# Altium schematic sheet 2225B_VumetreFrequenciel.SchDoc
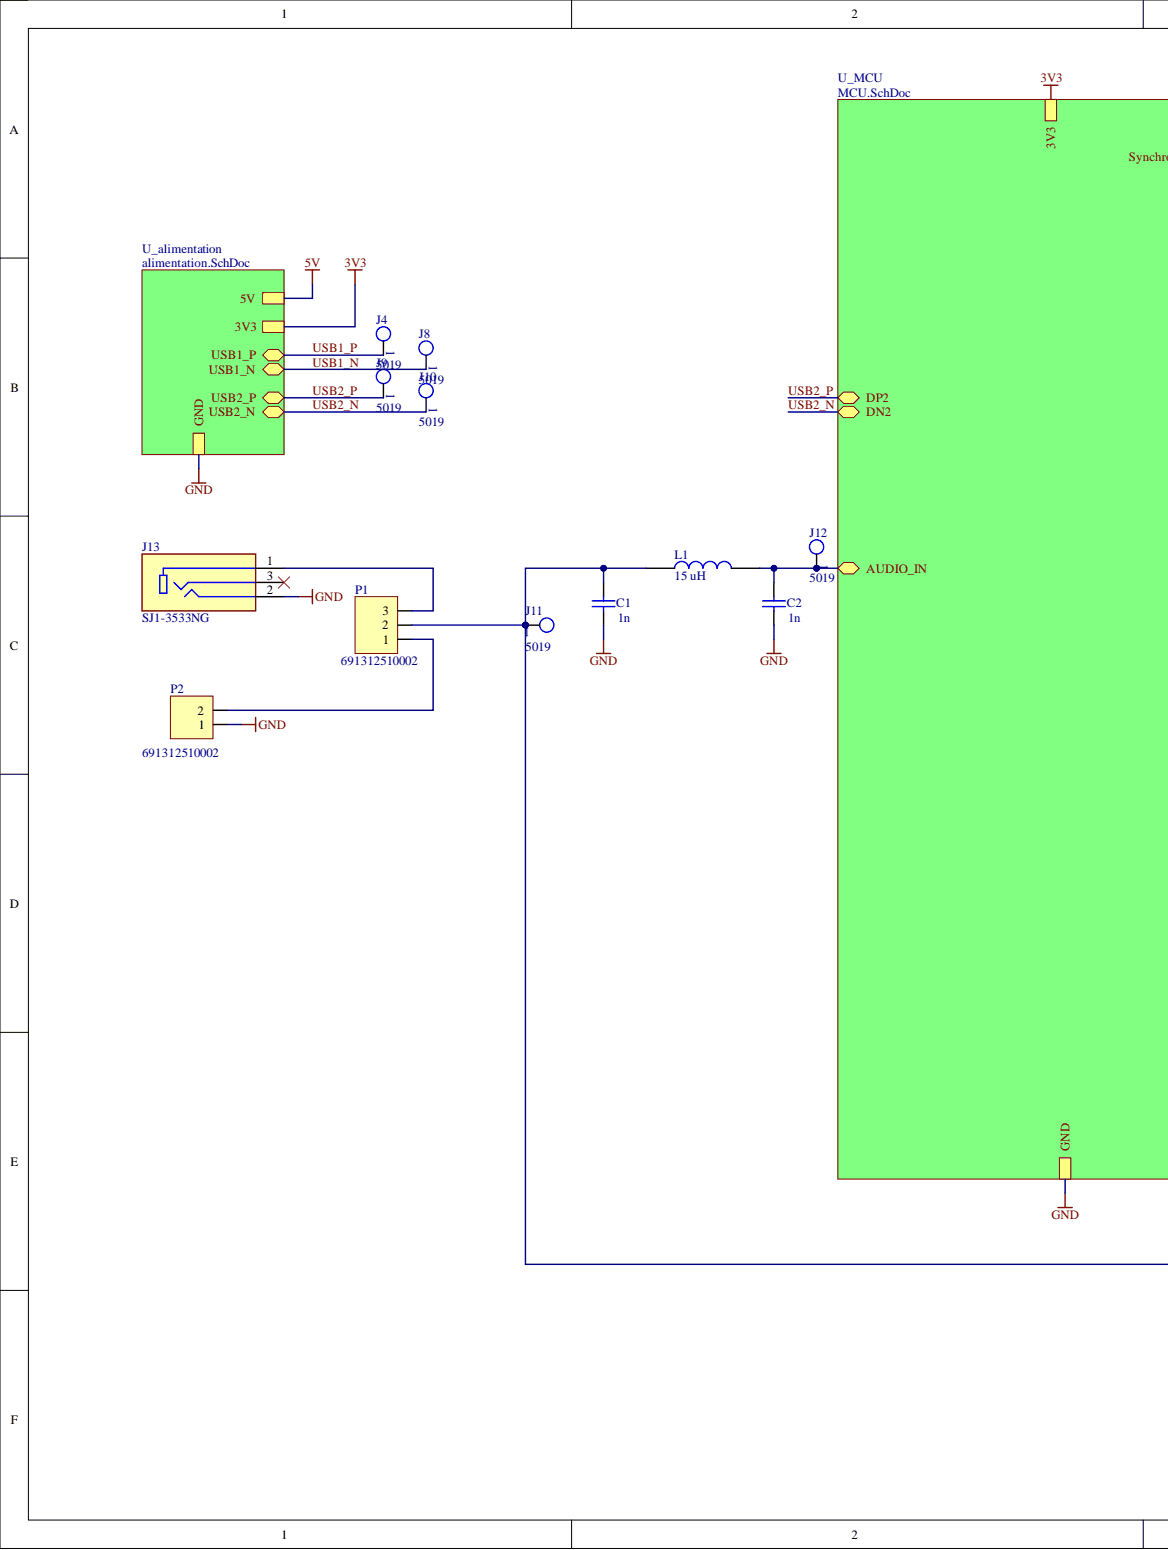
[diagram p0: 2225B_VumetreFrequenciel.SchDoc, left]
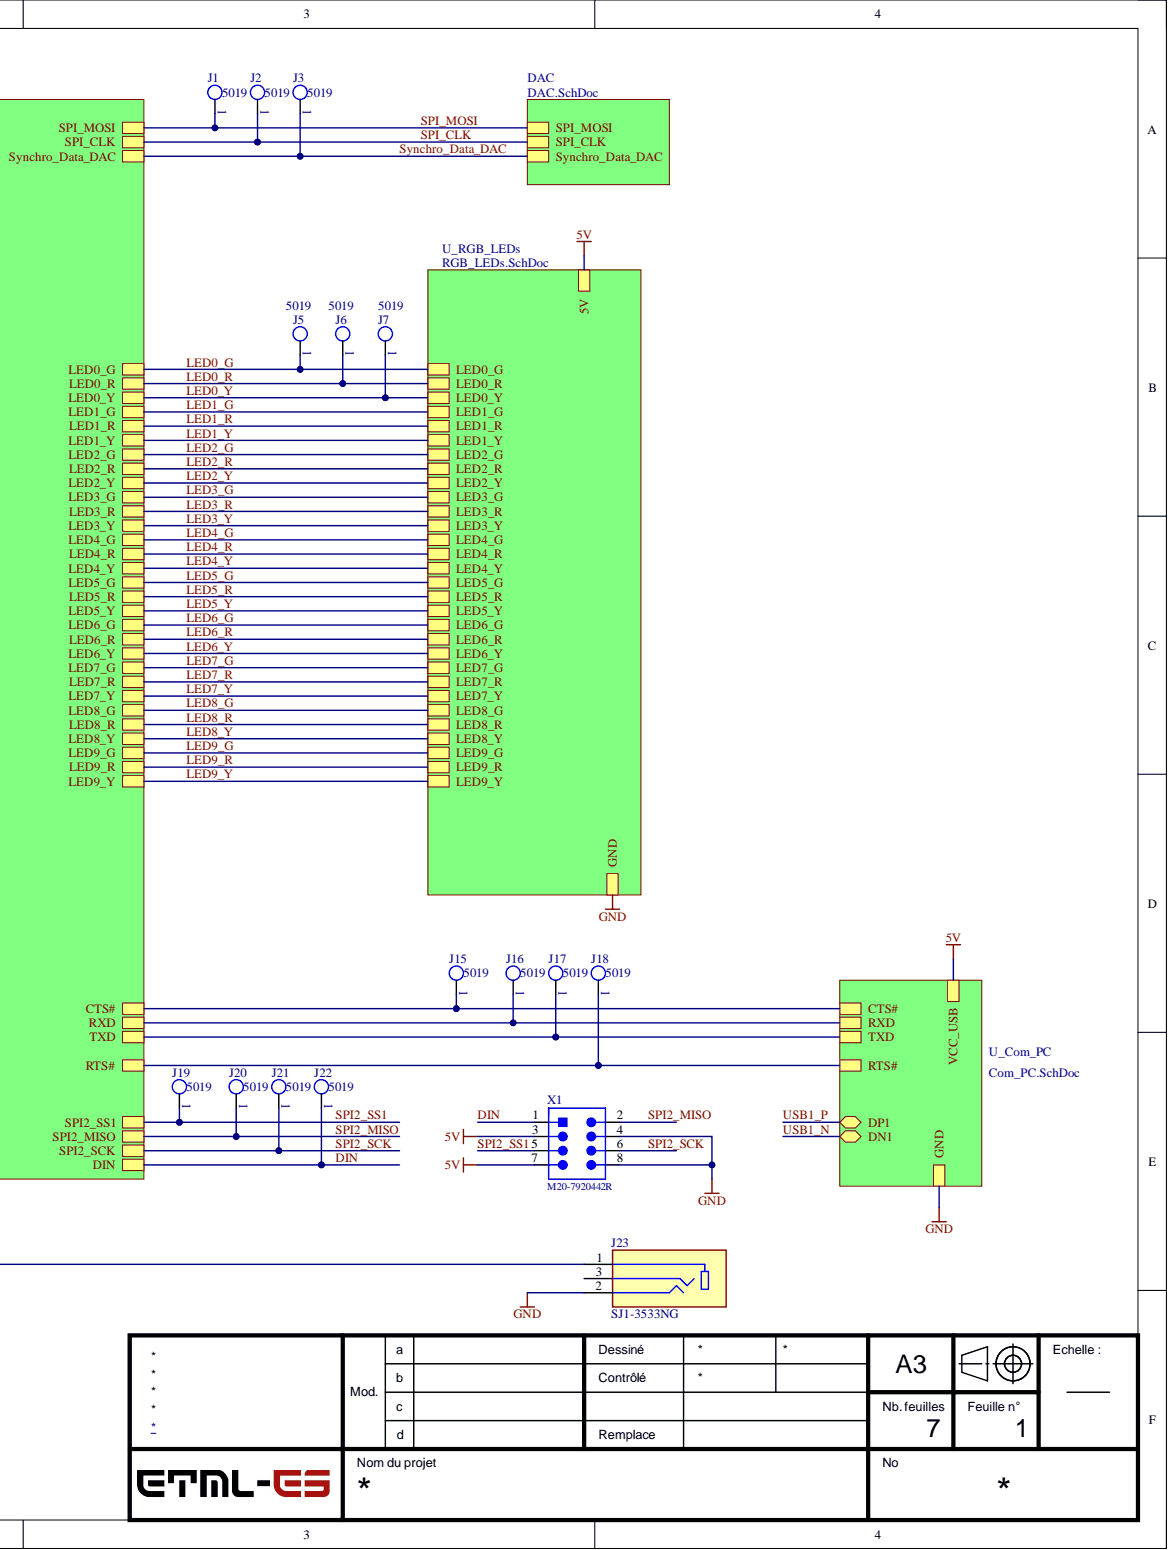
[diagram p1: 2225B_VumetreFrequenciel.SchDoc, right]

## Parts
C1  1n  [C0805]
C2  1n  [C0805]
J1  5019  [KEYSTONE_5019]
J2  5019  [KEYSTONE_5019]
J3  5019  [KEYSTONE_5019]
J4  5019  [KEYSTONE_5019]
J5  5019  [KEYSTONE_5019]
J6  5019  [KEYSTONE_5019]
J7  5019  [KEYSTONE_5019]
J8  5019  [KEYSTONE_5019]
J9  5019  [KEYSTONE_5019]
J10  5019  [KEYSTONE_5019]
J11  5019  [KEYSTONE_5019]
J12  5019  [KEYSTONE_5019]
J13  SJ1-3533NG  [CUI_SJ1-3533NG]
J15  5019  [KEYSTONE_5019]
J16  5019  [KEYSTONE_5019]
J17  5019  [KEYSTONE_5019]
J18  5019  [KEYSTONE_5019]
J19  5019  [KEYSTONE_5019]
J20  5019  [KEYSTONE_5019]
J21  5019  [KEYSTONE_5019]
J22  5019  [KEYSTONE_5019]
J23  SJ1-3533NG  [CUI_SJ1-3533NG]
L1  15 uH  [WE-PD_1210]
P1  691312510002  [61300311121]
P2  691312510002  [LINX_CONBNC002]
X1  M20-7920442R  [610108249121]

## Nets
5V: X1.3 X1.7
GND: C1.2 C2.2 J13.2 J23.2 P2.1 X1.4 X1.8
DIN: J22.1 X1.1
LED0_G: J5.1
LED0_R: J6.1
LED0_Y: J7.1
SPI2_MISO: J20.1 X1.2
SPI2_SCK: J21.1 X1.6
SPI2_SS1: J19.1 X1.5
SPI_CLK: J2.1
SPI_MOSI: J1.1
Synchro_Data_DAC: J3.1
USB1_N: J8.1
USB1_P: J4.1
USB2_N: J10.1
USB2_P: J9.1
NetC1_1: C1.1 J11.1 J23.1 L1.1 P1.2
NetC2_1: C2.1 J12.1 L1.2
NetJ13_1: J13.1 P1.3
NetJ13_3: J13.3
NetJ15_1: J15.1
NetJ16_1: J16.1
NetJ17_1: J17.1
NetJ18_1: J18.1
NetJ23_3: J23.3
NetP1_1: P1.1 P2.2
3V3:
LED1_G:
LED1_R:
LED1_Y:
LED2_G:
LED2_R:
LED2_Y:
LED3_G:
LED3_R:
LED3_Y:
LED4_G:
LED4_R:
LED4_Y:
LED5_G:
LED5_R:
LED5_Y:
LED6_G:
LED6_R:
LED6_Y:
LED7_G:
LED7_R:
LED7_Y:
LED8_G:
LED8_R:
LED8_Y:
LED9_G:
LED9_R:
LED9_Y:

## Library data of the parts
C1 C2 = Cap: Capacitor; Component Kind: Standard; LatestRevisionDate: 17-Jul-2002; LatestRevisionNote: Re-released for DXP Platform.; Library Name: Miscellaneous Devices.IntLib; PackageDescription: Radial Cap, Thru-Hole; 2 Leads; 0.3 in Pin Spacing; PackageReference: RAD-0.3; Published: 8-Jun-2000; Signal Integrity: Cap; Simulation: CAP; Value: 1n; Publisher: Altium Limited
J1-J12 J15-J22 = CMP-1672-00008-2: Manufacturer: Keystone Electronics; NexarPartId: 22052288; Number of Contacts: 1; Component Kind: Standard; Contact Material: Bronze, Silver; Contact Plating: Silver; Height: 2.286mm; Lead Free: Lead Free; Manufacturer Part Number: 5019; Material: Bronze; Mount: Surface Mount; Number of Pins: 1; Packaging: Tape and Reel; REACH SVHC: No SVHC; RoHS: Compliant; Termination: SMD/SMT; Library Name: 2225B_VumetreFrequenciel.SchLib
J13 J23 = SJ1-3533NG: 3.5 mm Right-Angle Stereo Jack, 3 Pin PCB Mount, Isolated Ground; MF: {'manufacturer': u'CUI'}; Availability: Unavailable; Component Kind: Standard; MP: SJ1-3533NG; Package: None; Price: None; Library Name: 2227_BoiteBruitage.SchLib
L1 = CDRH125NP-220MC: Fixed Inductors 22uH 2.80A 0.028ohms; Manufacturer_Name: Sumida; Geometry.Height: 6mm; Component Kind: Standard; Datasheet Link: http://products.sumida.com/products/pdf/CDRH125,CDRH125LD.pdf; Manufacturer_Part_Number: CDRH125NP-220MC; Library Name: TP2_Convertisseur_Courant.SchLib
P1 = MHDR1X3: Header, 3-Pin; Component Kind: Standard; LatestRevisionDate: 17-Jul-2002; LatestRevisionNote: Re-released for DXP Platform.; Signal Integrity: Connector; Library Name: 2225B_VumetreFrequenciel.SchLib; Publisher: Altium Limited
P2 = MHDR1X2: Header, 2-Pin; Component Kind: Standard; LatestRevisionDate: 17-Jul-2002; LatestRevisionNote: Re-released for DXP Platform.; Signal Integrity: Connector; Library Name: 2225B_VumetreFrequenciel.SchLib; Publisher: Altium Limited
X1 = 610108249121: WR-PHD Pin Header, THT, pitch 2.54mm, Dual Row, Angled, 8p; Manufacturer: Wurth Elektronik; Manufacturer Part Number: 61300821021; Contact Resistance: 20mOhm; Pin Number: 8; Rated Current: 3A; Withstanding Voltage: 500VAC; Working Voltage: 250VAC; Component Kind: Standard; ComponentLink1Description: Manufacturer; ComponentLink1URL: http://www.we-online.de; ComponentLink2Description: Datasheet; ComponentLink2URL: https://www.we-online.com/catalog/datasheet/61300821021.pdf; Gender: Pin Header; Mounting Technology: THT; Operating Temperature: -40 to +105degC; Orientation: Angled; Packaging: Bag; Pitch: 2.54mm; Row Number: Dual; Library Name: 2126_AffichageMatriciel.SchLib
